# Altium schematic sheet Sensing2.SchDoc
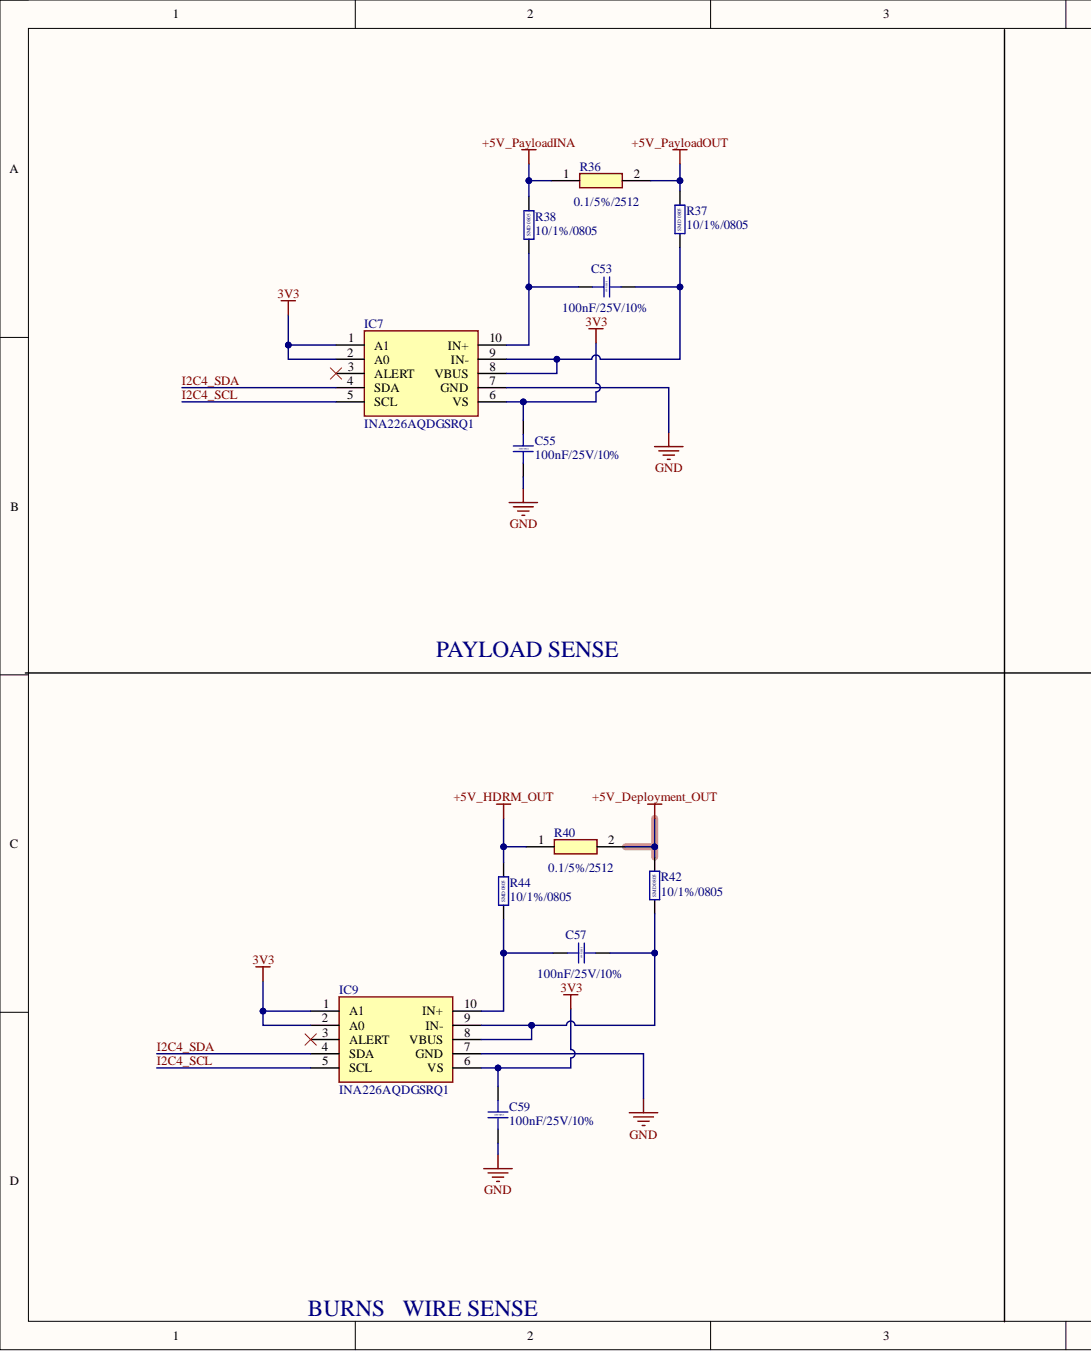
[diagram p0: Sensing2.SchDoc, left]
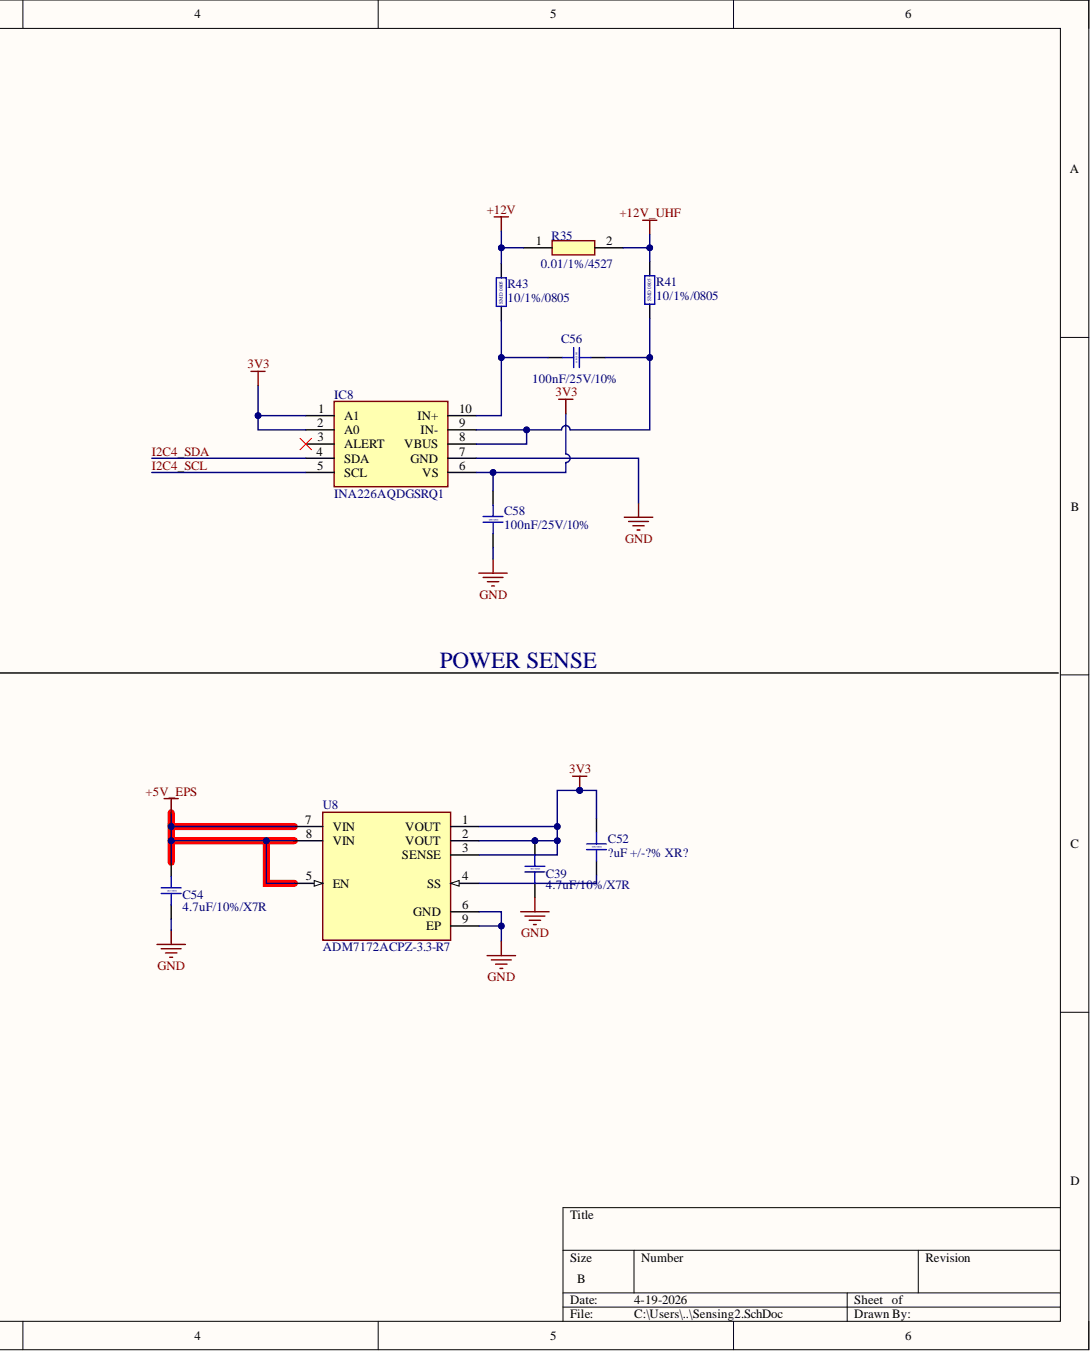
[diagram p1: Sensing2.SchDoc, right]

## Parts
C39  4.7uF/10%/X7R  [CAP_1210]
C52  ?uF +/-?% XR?  [CAP_0402]
C53  100nF/25V/10%  [CAP_0603]
C54  4.7uF/10%/X7R  [CAP_1210]
C55  100nF/25V/10%  [CAP_0603]
C56  100nF/25V/10%  [CAP_0603]
C57  100nF/25V/10%  [CAP_0603]
C58  100nF/25V/10%  [CAP_0603]
C59  100nF/25V/10%  [CAP_0603]
IC7  INA226AQDGSRQ1  [SOP50P490X110-10N]
IC8  INA226AQDGSRQ1  [SOP50P490X110-10N]
IC9  INA226AQDGSRQ1  [SOP50P490X110-10N]
R35  0.01/1%/4527  [RESM11670X254N]
R36  0.1/5%/2512  [RESC6331X80N]
R37  10/1%/0805  [RES_0805]
R38  10/1%/0805  [RES_0805]
R40  0.1/5%/2512  [RESC6331X80N]
R41  10/1%/0805  [RES_0805]
R42  10/1%/0805  [RES_0805]
R43  10/1%/0805  [RES_0805]
R44  10/1%/0805  [RES_0805]
U8  ADM7172ACPZ-3.3-R7  [FP-CP-8-21-IPC_C]

## Nets
3V3: C39.2 C52.2 C55.2 C58.2 C59.2 IC7.1(A1) IC7.2(A0) IC7.6(VS) IC8.1(A1) IC8.2(A0) IC8.6(VS) IC9.1(A1) IC9.2(A0) IC9.6(VS) U8.1(VOUT) U8.2(VOUT) U8.3(SENSE)
+5V_Deployment_OUT: R40.2 R42.2
+5V_EPS: C54.2 U8.5(EN) U8.7(VIN) U8.8(VIN)
+5V_HDRM_OUT: R40.1 R44.2
+5V_PayloadINA: R36.1 R38.2
+5V_PayloadOUT: R36.2 R37.2
+12V: R35.1 R43.2
+12V_UHF: R35.2 R41.2
GND: C39.1 C54.1 C55.1 C58.1 C59.1 IC7.7(GND) IC8.7(GND) IC9.7(GND) U8.6(GND) U8.9(EP)
I2C4_SCL: IC7.5(SCL) IC8.5(SCL) IC9.5(SCL)
I2C4_SDA: IC7.4(SDA) IC8.4(SDA) IC9.4(SDA)
NetC52_1: C52.1 U8.4(SS)
NetC53_1: C53.1 IC7.8(VBUS) IC7.9(IN-) R37.1
NetC53_2: C53.2 IC7.10(IN+) R38.1
NetC56_1: C56.1 IC8.8(VBUS) IC8.9(IN-) R41.1
NetC56_2: C56.2 IC8.10(IN+) R43.1
NetC57_1: C57.1 IC9.8(VBUS) IC9.9(IN-) R42.1
NetC57_2: C57.2 IC9.10(IN+) R44.1

Not connected: IC7 3(ALERT); IC8 3(ALERT); IC9 3(ALERT)

## Library data of the parts
C39 C54 = Capacitor-SMD-1210: Capacitor SMD 1210 RATED; Component Kind: Standard; Library Name: STeRG-Passives-Standard.SchLib
C52 = Capacitor-SMD-0402: Capacitor SMD 0402 RATED; Component Kind: Standard; Library Name: STeRG-Passives-Standard.SchLib
C53 C55-C59 = Capacitor-SMD-0603: C0603C104K3RACTU; Component Kind: Standard; Library Name: STeRG-Passives-Standard.SchLib
IC7-IC9 = INA226AQDGSRQ1: Integrated Circuit; Manufacturer_Name: Texas Instruments; Arrow Part Number: INA226AQDGSRQ1; Arrow Price/Stock: https://www.arrow.com/en/products/ina226aqdgsrq1/texas-instruments?utm_currency=USD&region=nac; Component Kind: Standard; Datasheet Link: http://www.ti.com/lit/gpn/ina226-q1; Height: 1.1mm; Library Name: SamacSys.SchLib; Manufacturer_Part_Number: INA226AQDGSRQ1; Mouser Part Number: 595-INA226AQDGSRQ1; Mouser Price/Stock: https://www.mouser.co.uk/ProductDetail/Texas-Instruments/INA226AQDGSRQ1?qs=uNh9J9Y3wTf1B1%2FBFFp7SA%3D%3D
R35 = WSR5R0100FEA: Resistor; Manufacturer_Name: Vishay; Arrow Part Number: WSR5R0100FEA; Arrow Price/Stock: https://www.arrow.com/en/products/wsr5r0100fea/vishay?region=nac; Component Kind: Standard; Datasheet Link: https://datasheet.datasheetarchive.com/originals/distributors/DKDS-23/453328.pdf; Height: 2.537mm; Manufacturer_Part_Number: WSR5R0100FEA; Mouser Part Number: 71-WSR5R0100FEA; Mouser Price/Stock: https://www.mouser.co.uk/ProductDetail/Vishay-Dale/WSR5R0100FEA?qs=4Ewz1atfbqI%252BdJPHTSy7jg%3D%3D
R36 R40 = CRM2512-JX-R100ELF: Resistor; Manufacturer_Name: Bourns; Arrow Part Number: CRM2512-JX-R100ELF; Arrow Price/Stock: https://www.arrow.com/en/products/crm2512-jx-r100elf/bourns; Component Kind: Standard; Datasheet Link: http://www.bourns.com/data/global/pdfs/CRM0805_1206_2010.pdf; Height: 0.8mm; Manufacturer_Part_Number: CRM2512-JX-R100ELF; Mouser Part Number: 652-CRM2512JXR100ELF; Mouser Price/Stock: https://www.mouser.co.uk/ProductDetail/Bourns/CRM2512-JX-R100ELF?qs=fxrnC0PBR6XYVKzZND0J4Q%3D%3D
R37 R38 R41-R44 = Resistor-SMD-0805: CRCW080510R0FKEA; Component Kind: Standard; Library Name: STeRG-Passives-Standard.SchLib
U8 = CMP-09138-000009-1: IC REG LINEAR 3.3V 2A 8LFCSP; Manufacturer: Analog Devices; Accuracy Percentage: 1.5%; Dropout Voltage: 172mV; Max Junction Temp: +125°C; Max Supply Voltage: 6.5V; Min Supply Voltage: 2.3V; Nominal Supply Current: 0.7-4.8mA; Number Of Outputs: 1; Output Type: Fixed; Standoff Height: 0.02mm; Automotive: No; Category: IC; Component Kind: Standard; ComponentLink2Description: Datasheet; ComponentLink2URL: https://www.analog.com/media/en/technical-documentation/data-sheets/ADM7172.pdf; Device Class L1: Integrated Circuits (ICs); Device Class L2: Power Management ICs; Device Class L3: Voltage Regulators - Linear; Digikey Description: IC REG LINEAR 3.3V 2A 8LFCSP; Height: 0.8mm; Lead Free: Yes; Library Name: Altium Content Vault; Manufacturer Part Number: ADM7172ACPZ-3.3-R7; Mouser Description: LDO Regulator Pos 3.3V 2A Medical 8-Pin LFCSP EP T/R; Octopart: https://octopart.com/adm7172acpz-3.3-r7-analog+devices-49227344; Output Current: 2A; Output Voltage: 3.3V; Package: CP-8-21; RoHS: TRUE; Temperature: -40°C to +125°C; Temperature Range High: +125°C; Temperature Range Low: -40°C
